# Altium schematic sheet main.SchDoc(main)
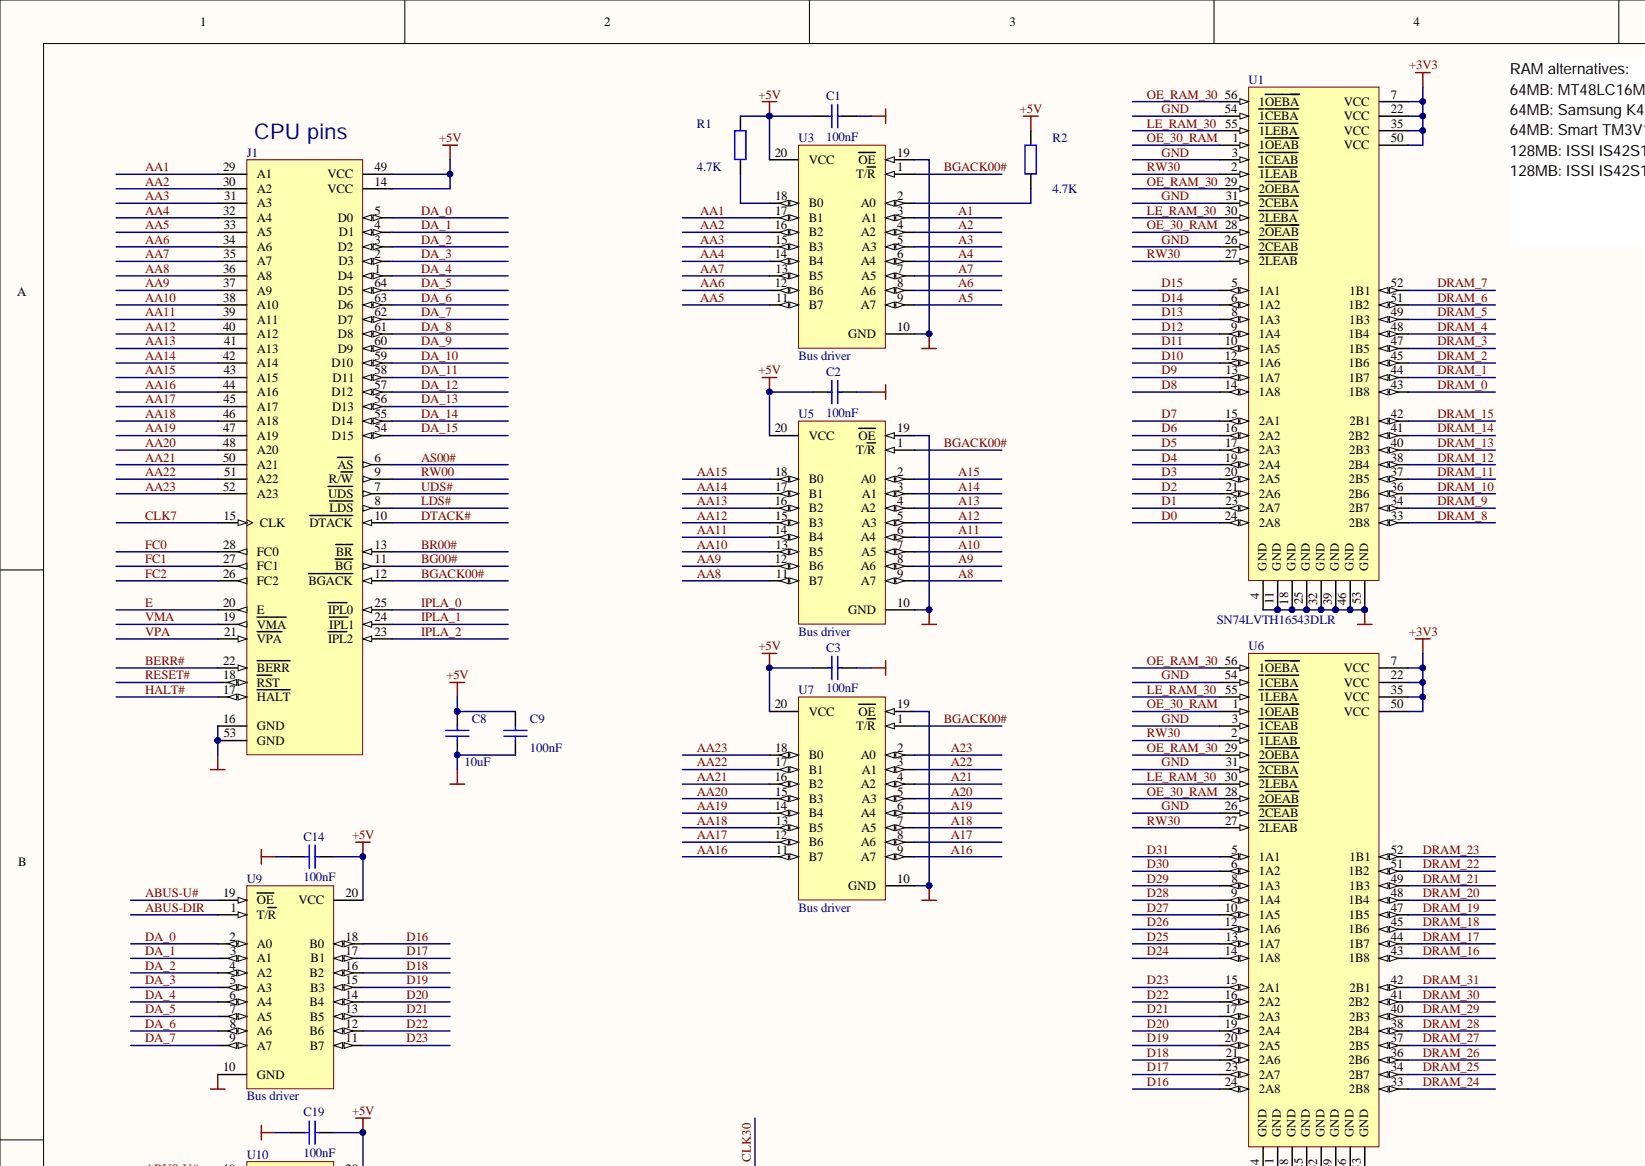
[diagram p0: main.SchDoc, top left]
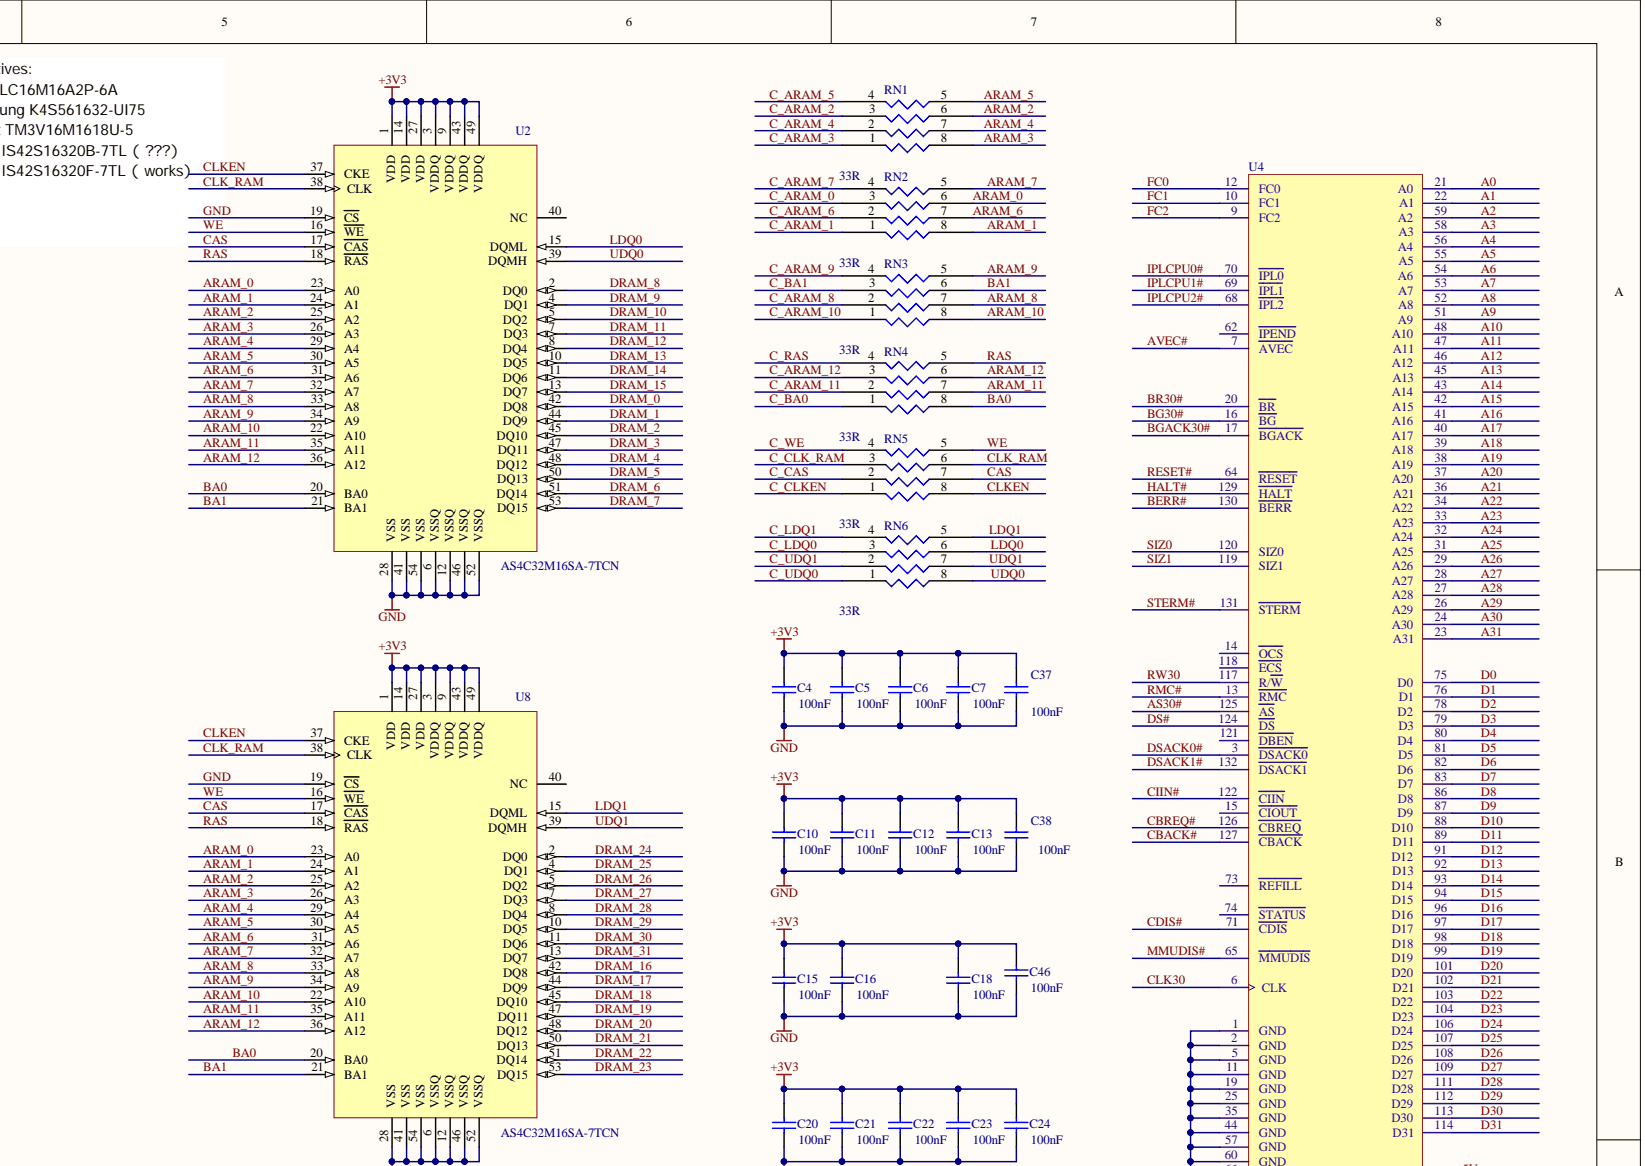
[diagram p1: main.SchDoc, top right]
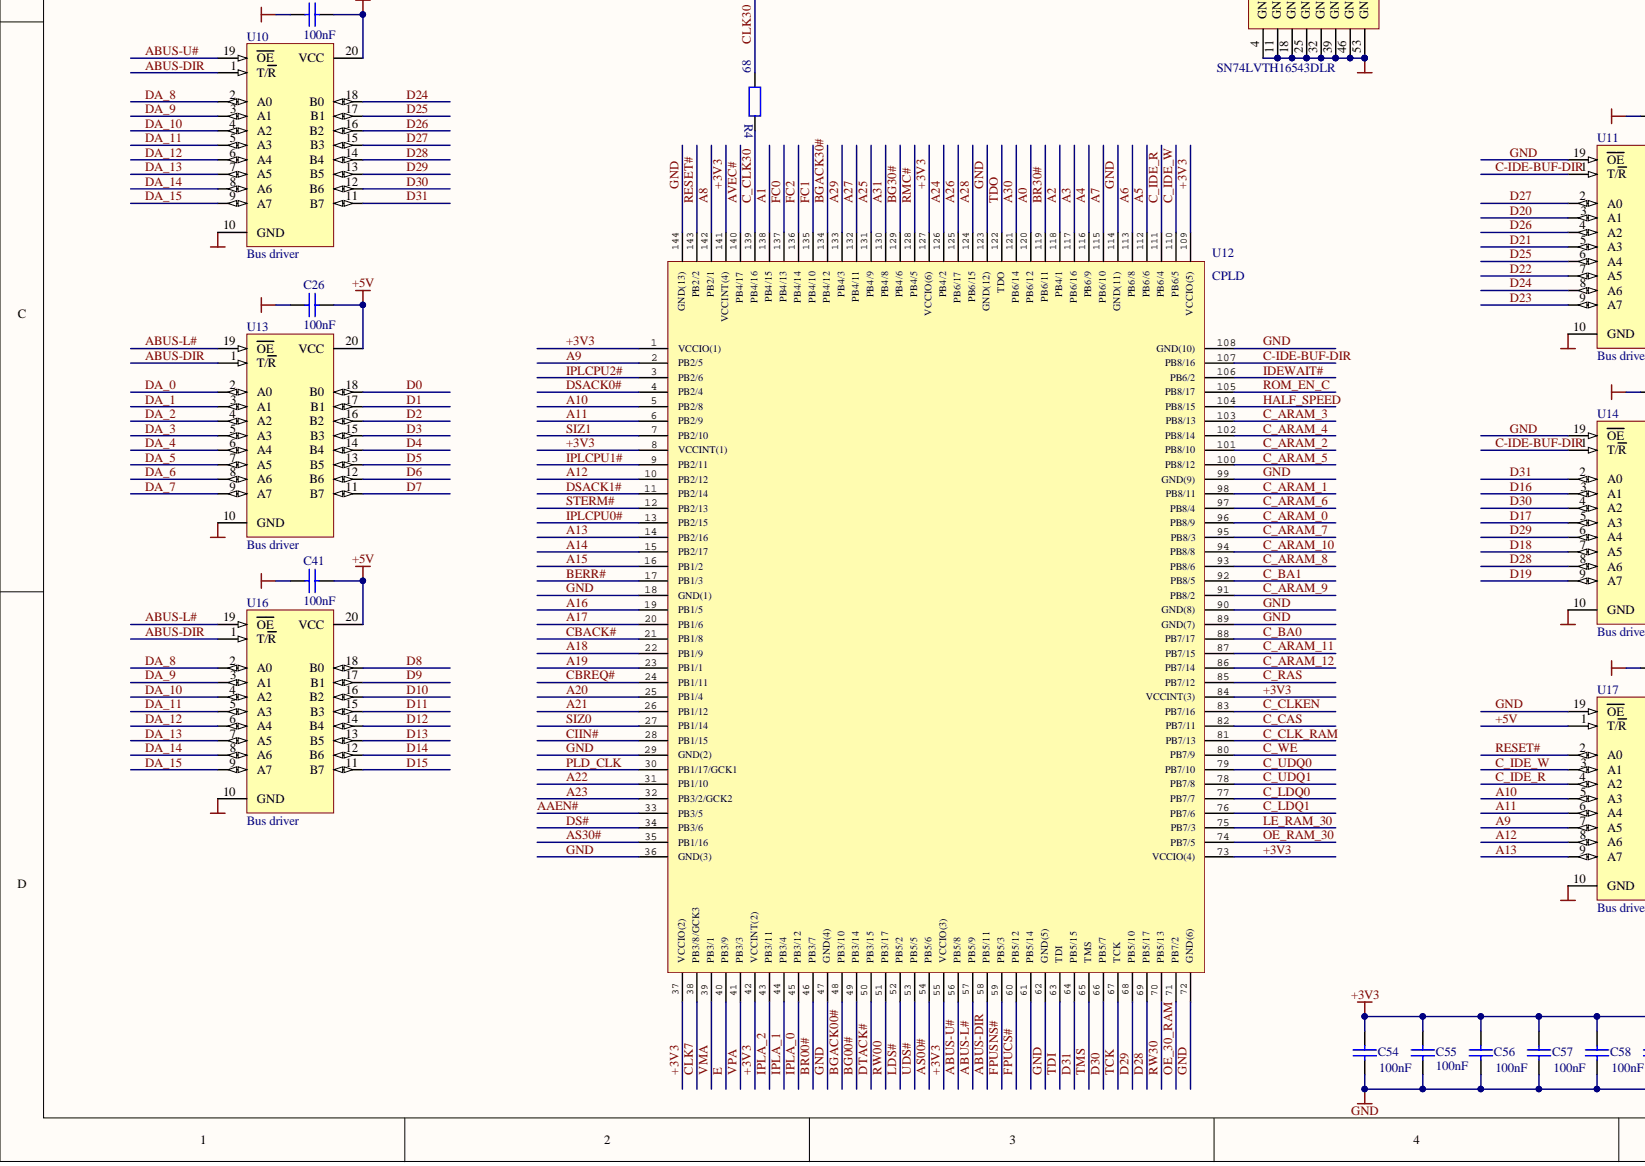
[diagram p2: main.SchDoc, bottom left]
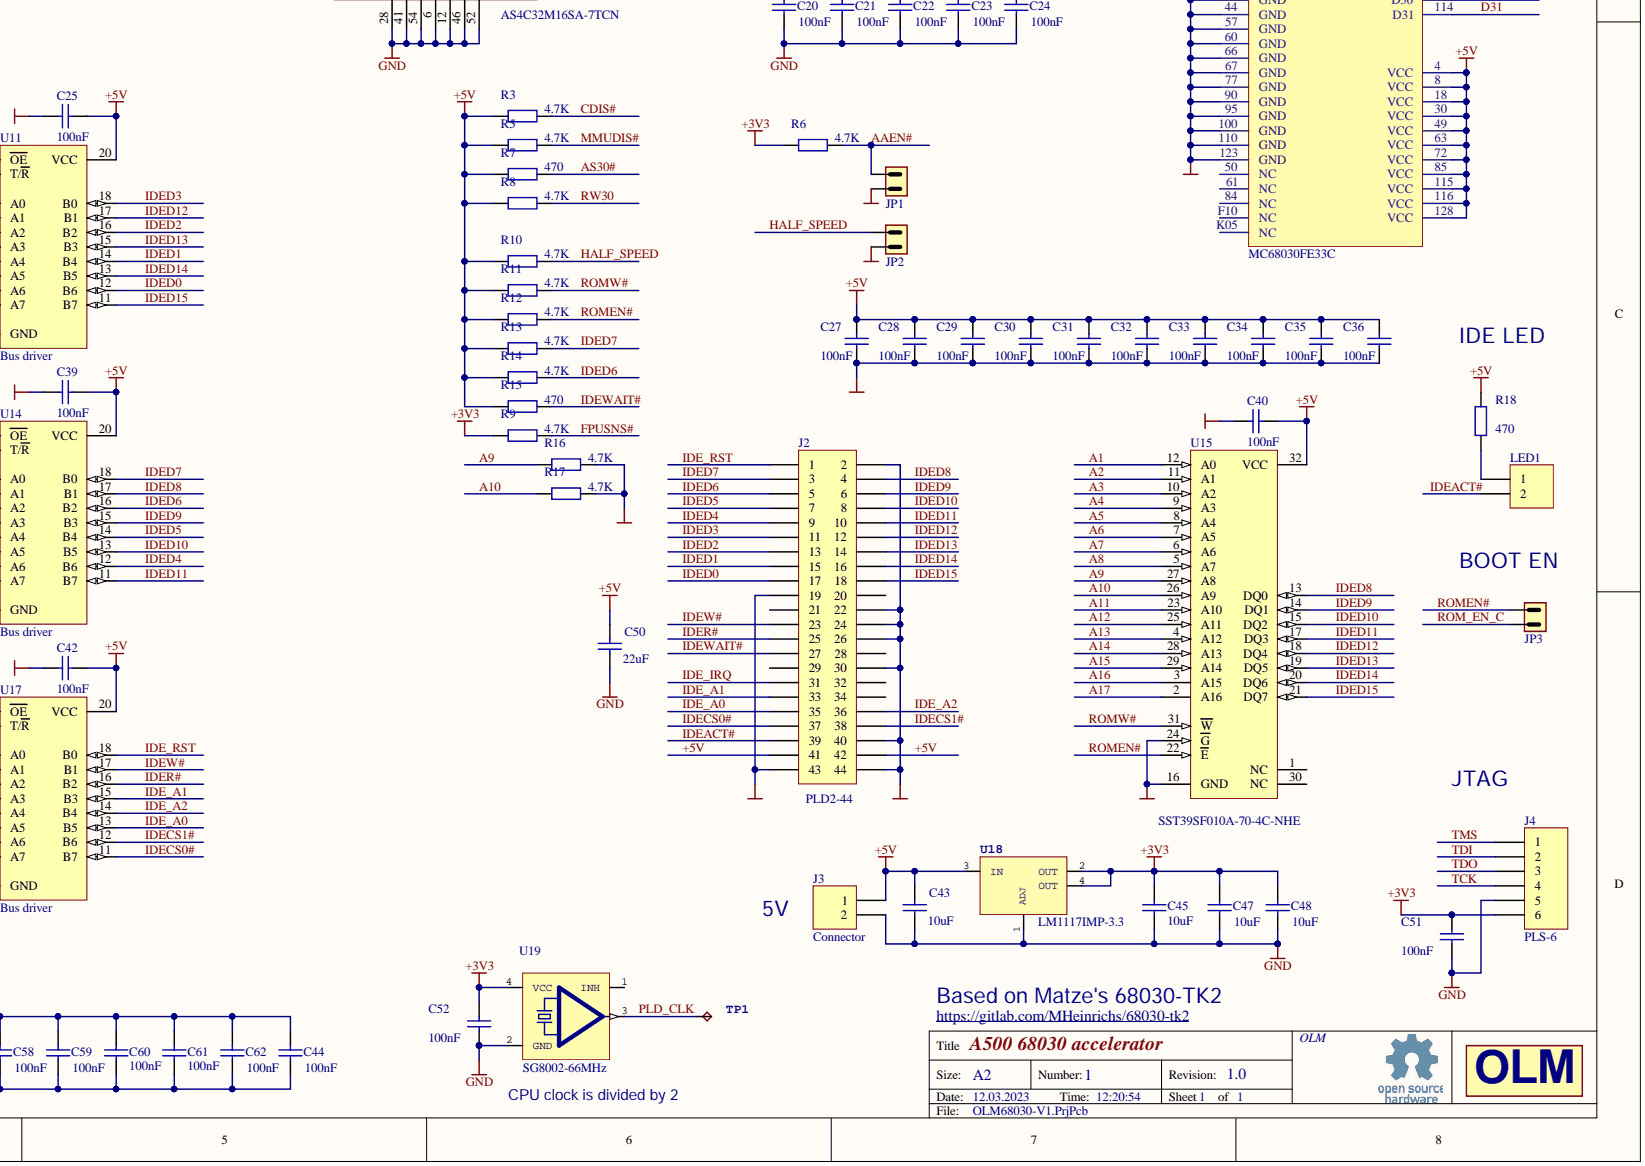
[diagram p3: main.SchDoc, bottom right]

## Parts
A1  OSHW  [OSHW-LOGO-10MM]  (value not drawn)  (no BOM)
C1  value 100nF  [SM/C_0603]  (hidden comment: Capacitor)
C2  value 100nF  [SM/C_0603]  (hidden comment: Capacitor)
C3  value 100nF  [SM/C_0603]  (hidden comment: Capacitor)
C4  value 100nF  [SM/C_0603]  (hidden comment: Capacitor)
C5  value 100nF  [SM/C_0603]  (hidden comment: Capacitor)
C6  value 100nF  [SM/C_0603]  (hidden comment: Capacitor)
C7  value 100nF  [SM/C_0603]  (hidden comment: Capacitor)
C8  value 10uF  [SM/C_1206]  (hidden comment: Capacitor)
C9  value 100nF  [SM/C_0603]  (hidden comment: Capacitor)
C10  value 100nF  [SM/C_0603]  (hidden comment: Capacitor)
C11  value 100nF  [SM/C_0603]  (hidden comment: Capacitor)
C12  value 100nF  [SM/C_0603]  (hidden comment: Capacitor)
C13  value 100nF  [SM/C_0603]  (hidden comment: Capacitor)
C14  value 100nF  [SM/C_0603]  (hidden comment: Capacitor)
C15  value 100nF  [SM/C_0603]  (hidden comment: Capacitor)
C16  value 100nF  [SM/C_0603]  (hidden comment: Capacitor)
C18  value 100nF  [SM/C_0603]  (hidden comment: Capacitor)
C19  value 100nF  [SM/C_0603]  (hidden comment: Capacitor)
C20  value 100nF  [SM/C_0603]  (hidden comment: Capacitor)
C21  value 100nF  [SM/C_0603]  (hidden comment: Capacitor)
C22  value 100nF  [SM/C_0603]  (hidden comment: Capacitor)
C23  value 100nF  [SM/C_0603]  (hidden comment: Capacitor)
C24  value 100nF  [SM/C_0603]  (hidden comment: Capacitor)
C25  value 100nF  [SM/C_0603]  (hidden comment: Capacitor)
C26  value 100nF  [SM/C_0603]  (hidden comment: Capacitor)
C27  value 100nF  [SM/C_0603]  (hidden comment: Capacitor)
C28  value 100nF  [SM/C_0603]  (hidden comment: Capacitor)
C29  value 100nF  [SM/C_0603]  (hidden comment: Capacitor)
C30  value 100nF  [SM/C_0603]  (hidden comment: Capacitor)
C31  value 100nF  [SM/C_0603]  (hidden comment: Capacitor)
C32  value 100nF  [SM/C_0603]  (hidden comment: Capacitor)
C33  value 100nF  [SM/C_0603]  (hidden comment: Capacitor)
C34  value 100nF  [SM/C_0603]  (hidden comment: Capacitor)
C35  value 100nF  [SM/C_0603]  (hidden comment: Capacitor)
C36  value 100nF  [SM/C_0603]  (hidden comment: Capacitor)
C37  value 100nF  [SM/C_0603]  (hidden comment: Capacitor)
C38  value 100nF  [SM/C_0603]  (hidden comment: Capacitor)
C39  value 100nF  [SM/C_0603]  (hidden comment: Capacitor)
C40  value 100nF  [SM/C_0603]  (hidden comment: Capacitor)
C41  value 100nF  [SM/C_0603]  (hidden comment: Capacitor)
C42  value 100nF  [SM/C_0603]  (hidden comment: Capacitor)
C43  value 10uF  [SM/C_1206]  (hidden comment: Capacitor)
C44  value 100nF  [SM/C_0603]  (hidden comment: Capacitor)
C45  value 10uF  [SM/C_1206]  (hidden comment: Capacitor)
C46  value 100nF  [SM/C_0603]  (hidden comment: Capacitor)
C47  value 10uF  [SM/C_1206]  (hidden comment: Capacitor)
C48  value 10uF  [SM/C_1206]  (hidden comment: Capacitor)
C50  value 22uF  [SM/C_1206]  (hidden comment: Capacitor)
C51  value 100nF  [SM/C_0603]  (hidden comment: Capacitor)
C52  value 100nF  [SM/C_0603]  (hidden comment: Capacitor)
C54  value 100nF  [SM/C_0603]  (hidden comment: Capacitor)
C55  value 100nF  [SM/C_0603]  (hidden comment: Capacitor)
C56  value 100nF  [SM/C_0603]  (hidden comment: Capacitor)
C57  value 100nF  [SM/C_0603]  (hidden comment: Capacitor)
C58  value 100nF  [SM/C_0603]  (hidden comment: Capacitor)
C59  value 100nF  [SM/C_0603]  (hidden comment: Capacitor)
C60  value 100nF  [SM/C_0603]  (hidden comment: Capacitor)
C61  value 100nF  [SM/C_0603]  (hidden comment: Capacitor)
C62  value 100nF  [SM/C_0603]  (hidden comment: Capacitor)
J1  Header pins  [DIP.100/64/W.900/L3.225-HEADER]  (value not drawn)
J2  Connector  value PLD2-44  [PLD2-44]
J3  Connector  [pls-2]
J4  Connector  value PLS-6  [PLS-6]  (no BOM)
JP1  Connector  [pls-2]  (no BOM)
JP2  Connector  [pls-2]  (no BOM)
JP3  Connector  [pls-2]
LED1  Connector  [pls-2]
R1  value 4.7K  [SM/R_0603]  (hidden comment: Resistor)
R2  value 4.7K  [SM/R_0603]  (hidden comment: Resistor)
R3  value 4.7K  [SM/R_0603]  (hidden comment: Resistor)
R4  value 68  [SM/R_0603]  (hidden comment: Resistor)
R5  value 4.7K  [SM/R_0603]  (hidden comment: Resistor)
R6  value 4.7K  [SM/R_0603]  (hidden comment: Resistor)
R7  value 470  [SM/R_0603]  (hidden comment: Resistor)
R8  value 4.7K  [SM/R_0603]  (hidden comment: Resistor)
R9  value 4.7K  [SM/R_0603]  (hidden comment: Resistor)
R10  value 4.7K  [SM/R_0603]  (hidden comment: Resistor)
R11  value 4.7K  [SM/R_0603]  (hidden comment: Resistor)
R12  value 4.7K  [SM/R_0603]  (hidden comment: Resistor)
R13  value 4.7K  [SM/R_0603]  (hidden comment: Resistor)
R14  value 4.7K  [SM/R_0603]  (hidden comment: Resistor)
R15  value 470  [SM/R_0603]  (hidden comment: Resistor)
R16  value 4.7K  [SM/R_0603]  (hidden comment: Resistor)
R17  value 4.7K  [SM/R_0603]  (hidden comment: Resistor)
R18  value 470  [SM/R_0603]  (hidden comment: Resistor)
RN1  Resistor network  [SM/RP_1206]  (value not drawn)
RN2  value 33R  [SM/RP_1206]  (hidden comment: Resistor network)
RN3  value 33R  [SM/RP_1206]  (hidden comment: Resistor network)
RN4  value 33R  [SM/RP_1206]  (hidden comment: Resistor network)
RN5  value 33R  [SM/RP_1206]  (hidden comment: Resistor network)
RN6  value 33R  [SM/RP_1206]  (hidden comment: Resistor network)
TP1  100MHz  [TP_d1.27]  (value not drawn)  (no BOM)
U1  Bus driver  value SN74LVTH16543DLR  [SSOP56]
U2  value AS4C32M16SA-7TCN  [TSOP2-54]  (hidden comment: SDRAM)
U3  Bus driver  [TSSOP20_6.5x4.4_0.65]
U4  value MC68030FE33C  [QUAD.025/132/WG1.085/CTX]  (hidden comment: CPU)
U5  Bus driver  [TSSOP20_6.5x4.4_0.65]
U6  Bus driver  value SN74LVTH16543DLR  [SSOP56]
U7  Bus driver  [TSSOP20_6.5x4.4_0.65]
U8  value AS4C32M16SA-7TCN  [TSOP2-54]  (hidden comment: SDRAM)
U9  Bus driver  [TSSOP20_6.5x4.4_0.65]
U10  Bus driver  [TSSOP20_6.5x4.4_0.65]
U11  Bus driver  [TSSOP20_6.5x4.4_0.65]
U12  CPLD  [QUAD.50M/144/WG22.00]
U13  Bus driver  [TSSOP20_6.5x4.4_0.65]
U14  Bus driver  [TSSOP20_6.5x4.4_0.65]
U15  value SST39SF010A-70-4C-NHE  [PLCC32-SOCKET]  (hidden comment: FLASH ROM)
U16  Bus driver  [TSSOP20_6.5x4.4_0.65]
U17  Bus driver  [TSSOP20_6.5x4.4_0.65]
U18  value LM1117IMP-3.3  [SM/SOT223_1234]  (hidden comment: LDO)
U19  value SG8002-66MHz  [SM/CRYSTAL_OSCILLATOR]  (hidden comment: OSCILLATOR)

## Nets
+3V3: C4.2 C5.2 C6.2 C7.2 C10.2 C11.2 C12.2 C13.2 C15.2 C16.2 C18.2 C20.2 C21.2 C22.2 C23.2 C24.2 C37.2 C38.2 C44.2 C45.1 C46.2 C47.2 C48.2 C51.2 C52.2 C54.2 C55.1 C56.2 C57.2 C58.2 C59.2 C60.1 C61.1 C62.2 J4.6 R6.1 R9.1 U1.7(VCC) U1.22(VCC) U1.35(VCC) U1.50(VCC) U2.1(VDD) U2.3(VDDQ) U2.9(VDDQ) U2.14(VDD) U2.27(VDD) U2.43(VDDQ) U2.49(VDDQ) U6.7(VCC) U6.22(VCC) U6.35(VCC) U6.50(VCC) U8.1(VDD) U8.3(VDDQ) U8.9(VDDQ) U8.14(VDD) U8.27(VDD) U8.43(VDDQ) U8.49(VDDQ) U12.1(VCCIO(1)) U12.8(VCCINT(1)) U12.37(VCCIO(2)) U12.42(VCCINT(2)) U12.55(VCCIO(3)) U12.73(VCCIO(4)) U12.84(VCCINT(3)) U12.109(VCCIO(5)) U12.127(VCCIO(6)) U12.141(VCCINT(4)) U18.2(OUT) U18.4(OUT) U19.4(VCC)
+5V: C1.2 C2.2 C3.2 C8.2 C9.2 C14.1 C19.1 C25.1 C26.1 C27.2 C28.2 C29.2 C30.2 C31.2 C32.2 C33.2 C34.2 C35.2 C36.2 C39.1 C40.1 C41.1 C42.1 C43.1 C50.1 J1.14(VCC) J1.49(VCC) J2.41 J2.42 J3.1 R1.2 R2.2 R3.1 R5.1 R7.1 R8.1 R10.1 R11.1 R12.1 R13.1 R14.1 R15.1 R18.2 U3.20(VCC) U4.4(VCC) U4.8(VCC) U4.18(VCC) U4.30(VCC) U4.49(VCC) U4.63(VCC) U4.72(VCC) U4.85(VCC) U4.115(VCC) U4.116(VCC) U4.128(VCC) U5.20(VCC) U7.20(VCC) U9.20(VCC) U10.20(VCC) U11.20(VCC) U13.20(VCC) U14.20(VCC) U15.32(VCC) U16.20(VCC) U17.1(T/R) U17.20(VCC) U18.3(IN)
A0: U4.21(A0) U12.120(PB6/12)
A1: U3.3(A1) U4.22(A1) U12.138(PB4/15) U15.12(A0)
A2: U3.4(A2) U4.59(A2) U12.118(PB4/1) U15.11(A1)
A3: U3.5(A3) U4.58(A3) U12.117(PB6/16) U15.10(A2)
A4: U3.6(A4) U4.56(A4) U12.116(PB6/9) U15.9(A3)
A5: U3.9(A7) U4.55(A5) U12.112(PB6/6) U15.8(A4)
A6: U3.8(A6) U4.54(A6) U12.113(PB6/8) U15.7(A5)
A7: U3.7(A5) U4.53(A7) U12.115(PB6/10) U15.6(A6)
A8: U4.52(A8) U5.9(A7) U12.142(PB2/1) U15.5(A7)
A9: R16.1 U4.51(A9) U5.8(A6) U12.2(PB2/5) U15.27(A8) U17.7(A5)
A10: R17.1 U4.48(A10) U5.7(A5) U12.5(PB2/8) U15.26(A9) U17.5(A3)
A11: U4.47(A11) U5.6(A4) U12.6(PB2/9) U15.23(A10) U17.6(A4)
A12: U4.46(A12) U5.5(A3) U12.10(PB2/12) U15.25(A11) U17.8(A6)
A13: U4.45(A13) U5.4(A2) U12.14(PB2/16) U15.4(A12) U17.9(A7)
A14: U4.43(A14) U5.3(A1) U12.15(PB2/17) U15.28(A13)
A15: U4.42(A15) U5.2(A0) U12.16(PB1/2) U15.29(A14)
A16: U4.41(A16) U7.9(A7) U12.19(PB1/5) U15.3(A15)
A17: U4.40(A17) U7.8(A6) U12.20(PB1/6) U15.2(A16)
A18: U4.39(A18) U7.7(A5) U12.22(PB1/9)
A19: U4.38(A19) U7.6(A4) U12.23(PB1/1)
A20: U4.37(A20) U7.5(A3) U12.25(PB1/4)
A21: U4.36(A21) U7.4(A2) U12.26(PB1/12)
A22: U4.34(A22) U7.3(A1) U12.31(PB1/10)
A23: U4.33(A23) U7.2(A0) U12.32(PB3/2/GCK2)
A24: U4.32(A24) U12.126(PB4/2)
A25: U4.31(A25) U12.131(PB4/9)
A26: U4.29(A26) U12.125(PB6/17)
A27: U4.28(A27) U12.132(PB4/11)
A28: U4.27(A28) U12.124(PB6/15)
A29: U4.26(A29) U12.133(PB4/3)
A30: U4.24(A30) U12.121(PB6/14)
A31: U4.23(A31) U12.130(PB4/8)
AA1: J1.29(A1) U3.17(B1)
AA2: J1.30(A2) U3.16(B2)
AA3: J1.31(A3) U3.15(B3)
AA4: J1.32(A4) U3.14(B4)
AA5: J1.33(A5) U3.11(B7)
AA6: J1.34(A6) U3.12(B6)
AA7: J1.35(A7) U3.13(B5)
AA8: J1.36(A8) U5.11(B7)
AA9: J1.37(A9) U5.12(B6)
AA10: J1.38(A10) U5.13(B5)
AA11: J1.39(A11) U5.14(B4)
AA12: J1.40(A12) U5.15(B3)
AA13: J1.41(A13) U5.16(B2)
AA14: J1.42(A14) U5.17(B1)
AA15: J1.43(A15) U5.18(B0)
AA16: J1.44(A16) U7.11(B7)
AA17: J1.45(A17) U7.12(B6)
AA18: J1.46(A18) U7.13(B5)
AA19: J1.47(A19) U7.14(B4)
AA20: J1.48(A20) U7.15(B3)
AA21: J1.50(A21) U7.16(B2)
AA22: J1.51(A22) U7.17(B1)
AA23: J1.52(A23) U7.18(B0)
AAEN#: JP1.2 R6.2 U12.33(PB3/5)
ABUS-DIR: U9.1(T/R) U10.1(T/R) U12.58(PB5/11) U13.1(T/R) U16.1(T/R)
ABUS-L#: U12.57(PB5/9) U13.19(OE) U16.19(OE)
ABUS-U#: U9.19(OE) U10.19(OE) U12.56(PB5/8)
ARAM_0: RN2.6 U2.23(A0) U8.23(A0)
ARAM_1: RN2.8 U2.24(A1) U8.24(A1)
ARAM_2: RN1.6 U2.25(A2) U8.25(A2)
ARAM_3: RN1.8 U2.26(A3) U8.26(A3)
ARAM_4: RN1.7 U2.29(A4) U8.29(A4)
ARAM_5: RN1.5 U2.30(A5) U8.30(A5)
ARAM_6: RN2.7 U2.31(A6) U8.31(A6)
ARAM_7: RN2.5 U2.32(A7) U8.32(A7)
ARAM_8: RN3.7 U2.33(A8) U8.33(A8)
ARAM_9: RN3.5 U2.34(A9) U8.34(A9)
ARAM_10: RN3.8 U2.22(A10) U8.22(A10)
ARAM_11: RN4.7 U2.35(A11) U8.35(A11)
ARAM_12: RN4.6 U2.36(A12) U8.36(A12)
AS00#: J1.6(AS) U12.54(PB5/6)
AS30#: R7.2 U4.125(AS) U12.35(PB1/16)
AVEC#: U4.7(AVEC) U12.140(PB4/17)
BA0: RN4.8 U2.20(BA0) U8.20(BA0)
BA1: RN3.6 U2.21(BA1) U8.21(BA1)
BERR#: J1.22(BERR) U4.130(BERR) U12.17(PB1/3)
BG00#: J1.11(BG) U12.49(PB3/14)
BG30#: U4.16(BG) U12.129(PB4/6)
BGACK00#: J1.12(BGACK) U3.1(T/R) U5.1(T/R) U7.1(T/R) U12.48(PB3/10)
BGACK30#: U4.17(BGACK) U12.134(PB4/12)
BR00#: J1.13(BR) U12.46(PB3/7)
BR30#: U4.20(BR) U12.119(PB6/11)
C-IDE-BUF-DIR: U11.1(T/R) U12.107(PB8/16) U14.1(T/R)
C_ARAM_0: RN2.3 U12.96(PB8/9)
C_ARAM_1: RN2.1 U12.98(PB8/11)
C_ARAM_2: RN1.3 U12.101(PB8/10)
C_ARAM_3: RN1.1 U12.103(PB8/13)
C_ARAM_4: RN1.2 U12.102(PB8/14)
C_ARAM_5: RN1.4 U12.100(PB8/12)
C_ARAM_6: RN2.2 U12.97(PB8/4)
C_ARAM_7: RN2.4 U12.95(PB8/3)
C_ARAM_8: RN3.2 U12.93(PB8/6)
C_ARAM_9: RN3.4 U12.91(PB8/2)
C_ARAM_10: RN3.1 U12.94(PB8/8)
C_ARAM_11: RN4.2 U12.87(PB7/15)
C_ARAM_12: RN4.3 U12.86(PB7/14)
C_BA0: RN4.1 U12.88(PB7/17)
C_BA1: RN3.3 U12.92(PB8/5)
C_CAS: RN5.2 U12.82(PB7/11)
C_CLK30: R4.1 U12.139(PB4/16)
C_CLK_RAM: RN5.3 U12.81(PB7/13)
C_CLKEN: RN5.1 U12.83(PB7/16)
C_IDE_R: U12.111(PB6/4) U17.4(A2)
C_IDE_W: U12.110(PB6/5) U17.3(A1)
C_LDQ0: RN6.3 U12.77(PB7/7)
C_LDQ1: RN6.4 U12.76(PB7/6)
C_RAS: RN4.4 U12.85(PB7/12)
C_UDQ0: RN6.1 U12.79(PB7/10)
C_UDQ1: RN6.2 U12.78(PB7/8)
C_WE: RN5.4 U12.80(PB7/9)
CAS: RN5.7 U2.17(CAS) U8.17(CAS)
CBACK#: U4.127(CBACK) U12.21(PB1/8)
CBREQ#: U4.126(CBREQ) U12.24(PB1/11)
CDIS#: R3.2 U4.71(CDIS)
CIIN#: U4.122(CIIN) U12.28(PB1/15)
CLK7: J1.15(CLK) U12.38(PB3/8/GCK3)
CLK30: R4.2 U4.6(CLK)
CLK_RAM: RN5.6 U2.38(CLK) U8.38(CLK)
CLKEN: RN5.8 U2.37(CKE) U8.37(CKE)
D0: U1.24(2A8) U4.75(D0) U13.18(B0)
D1: U1.23(2A7) U4.76(D1) U13.17(B1)
D2: U1.21(2A6) U4.78(D2) U13.16(B2)
D3: U1.20(2A5) U4.79(D3) U13.15(B3)
D4: U1.19(2A4) U4.80(D4) U13.14(B4)
D5: U1.17(2A3) U4.81(D5) U13.13(B5)
D6: U1.16(2A2) U4.82(D6) U13.12(B6)
D7: U1.15(2A1) U4.83(D7) U13.11(B7)
D8: U1.14(1A8) U4.86(D8) U16.18(B0)
D9: U1.13(1A7) U4.87(D9) U16.17(B1)
D10: U1.12(1A6) U4.88(D10) U16.16(B2)
D11: U1.10(1A5) U4.89(D11) U16.15(B3)
D12: U1.9(1A4) U4.91(D12) U16.14(B4)
D13: U1.8(1A3) U4.92(D13) U16.13(B5)
D14: U1.6(1A2) U4.93(D14) U16.12(B6)
D15: U1.5(1A1) U4.94(D15) U16.11(B7)
D16: U4.96(D16) U6.24(2A8) U9.18(B0) U14.3(A1)
D17: U4.97(D17) U6.23(2A7) U9.17(B1) U14.5(A3)
D18: U4.98(D18) U6.21(2A6) U9.16(B2) U14.7(A5)
D19: U4.99(D19) U6.20(2A5) U9.15(B3) U14.9(A7)
D20: U4.101(D20) U6.19(2A4) U9.14(B4) U11.3(A1)
D21: U4.102(D21) U6.17(2A3) U9.13(B5) U11.5(A3)
D22: U4.103(D22) U6.16(2A2) U9.12(B6) U11.7(A5)
D23: U4.104(D23) U6.15(2A1) U9.11(B7) U11.9(A7)
D24: U4.106(D24) U6.14(1A8) U10.18(B0) U11.8(A6)
D25: U4.107(D25) U6.13(1A7) U10.17(B1) U11.6(A4)
D26: U4.108(D26) U6.12(1A6) U10.16(B2) U11.4(A2)
D27: U4.109(D27) U6.10(1A5) U10.15(B3) U11.2(A0)
D28: U4.111(D28) U6.9(1A4) U10.14(B4) U12.69(PB5/17) U14.8(A6)
D29: U4.112(D29) U6.8(1A3) U10.13(B5) U12.68(PB5/10) U14.6(A4)
D30: U4.113(D30) U6.6(1A2) U10.12(B6) U12.66(PB5/7) U14.4(A2)
D31: U4.114(D31) U6.5(1A1) U10.11(B7) U12.64(PB5/15) U14.2(A0)
DA_0: J1.5(D0) U9.2(A0) U13.2(A0)
DA_1: J1.4(D1) U9.3(A1) U13.3(A1)
DA_2: J1.3(D2) U9.4(A2) U13.4(A2)
DA_3: J1.2(D3) U9.5(A3) U13.5(A3)
DA_4: J1.1(D4) U9.6(A4) U13.6(A4)
DA_5: J1.64(D5) U9.7(A5) U13.7(A5)
DA_6: J1.63(D6) U9.8(A6) U13.8(A6)
DA_7: J1.62(D7) U9.9(A7) U13.9(A7)
DA_8: J1.61(D8) U10.2(A0) U16.2(A0)
DA_9: J1.60(D9) U10.3(A1) U16.3(A1)
DA_10: J1.59(D10) U10.4(A2) U16.4(A2)
DA_11: J1.58(D11) U10.5(A3) U16.5(A3)
DA_12: J1.57(D12) U10.6(A4) U16.6(A4)
DA_13: J1.56(D13) U10.7(A5) U16.7(A5)
DA_14: J1.55(D14) U10.8(A6) U16.8(A6)
DA_15: J1.54(D15) U10.9(A7) U16.9(A7)
DRAM_0: U1.43(1B8) U2.42(DQ8)
DRAM_1: U1.44(1B7) U2.44(DQ9)
DRAM_2: U1.45(1B6) U2.45(DQ10)
DRAM_3: U1.47(1B5) U2.47(DQ11)
DRAM_4: U1.48(1B4) U2.48(DQ12)
DRAM_5: U1.49(1B3) U2.50(DQ13)
DRAM_6: U1.51(1B2) U2.51(DQ14)
DRAM_7: U1.52(1B1) U2.53(DQ15)
DRAM_8: U1.33(2B8) U2.2(DQ0)
DRAM_9: U1.34(2B7) U2.4(DQ1)
DRAM_10: U1.36(2B6) U2.5(DQ2)
DRAM_11: U1.37(2B5) U2.7(DQ3)
DRAM_12: U1.38(2B4) U2.8(DQ4)
DRAM_13: U1.40(2B3) U2.10(DQ5)
DRAM_14: U1.41(2B2) U2.11(DQ6)
DRAM_15: U1.42(2B1) U2.13(DQ7)
DRAM_16: U6.43(1B8) U8.42(DQ8)
DRAM_17: U6.44(1B7) U8.44(DQ9)
DRAM_18: U6.45(1B6) U8.45(DQ10)
DRAM_19: U6.47(1B5) U8.47(DQ11)
DRAM_20: U6.48(1B4) U8.48(DQ12)
DRAM_21: U6.49(1B3) U8.50(DQ13)
DRAM_22: U6.51(1B2) U8.51(DQ14)
DRAM_23: U6.52(1B1) U8.53(DQ15)
DRAM_24: U6.33(2B8) U8.2(DQ0)
DRAM_25: U6.34(2B7) U8.4(DQ1)
DRAM_26: U6.36(2B6) U8.5(DQ2)
DRAM_27: U6.37(2B5) U8.7(DQ3)
DRAM_28: U6.38(2B4) U8.8(DQ4)
DRAM_29: U6.40(2B3) U8.10(DQ5)
DRAM_30: U6.41(2B2) U8.11(DQ6)
DRAM_31: U6.42(2B1) U8.13(DQ7)
DS#: U4.124(DS) U12.34(PB3/6)
DSACK0#: U4.3(DSACK0) U12.4(PB2/4)
DSACK1#: U4.132(DSACK1) U12.11(PB2/14)
DTACK#: J1.10(DTACK) U12.50(PB3/15)
E: J1.20(E) U12.40(PB3/9)
FC0: J1.28(FC0) U4.12(FC0) U12.137(PB4/13)
FC1: J1.27(FC1) U4.10(FC1) U12.135(PB4/10)
FC2: J1.26(FC2) U4.9(FC2) U12.136(PB4/14)
FPUCS#: U12.60(PB5/12)
FPUSNS#: R9.2 U12.59(PB5/3)
GND: C1.1 C2.1 C3.1 C4.1 C5.1 C6.1 C7.1 C8.1 C9.1 C10.1 C11.1 C12.1 C13.1 C14.2 C15.1 C16.1 C18.1 C19.2 C20.1 C21.1 C22.1 C23.1 C24.1 C25.2 C26.2 C27.1 C28.1 C29.1 C30.1 C31.1 C32.1 C33.1 C34.1 C35.1 C36.1 C37.1 C38.1 C39.2 C40.2 C41.2 C42.2 C43.2 C44.1 C45.2 C46.1 C47.1 C48.1 C50.2 C51.1 C52.1 C54.1 C55.2 C56.1 C57.1 C58.1 C59.1 C60.2 C61.2 C62.1 J1.16(GND) J1.53(GND) J2.2 J2.19 J2.22 J2.24 J2.26 J2.30 J2.40 J2.43 J2.44 J3.2 J4.5 JP1.1 JP2.1 R16.2 R17.2 U1.3(1CEAB) U1.4(GND) U1.11(GND) U1.18(GND) U1.25(GND) U1.26(2CEAB) U1.31(2CEBA) U1.32(GND) U1.39(GND) U1.46(GND) U1.53(GND) U1.54(1CEBA) U2.6(VSSQ) U2.12(VSSQ) U2.19(CS) U2.28(VSS) U2.41(VSS) U2.46(VSSQ) U2.52(VSSQ) U2.54(VSS) U3.10(GND) U3.19(OE) U4.1(GND) U4.2(GND) U4.5(GND) U4.11(GND) U4.19(GND) U4.25(GND) U4.35(GND) U4.44(GND) U4.57(GND) U4.60(GND) U4.66(GND) U4.67(GND) U4.77(GND) U4.90(GND) U4.95(GND) U4.100(GND) U4.110(GND) U4.123(GND) U5.10(GND) U5.19(OE) U6.3(1CEAB) U6.4(GND) U6.11(GND) U6.18(GND) U6.25(GND) U6.26(2CEAB) U6.31(2CEBA) U6.32(GND) U6.39(GND) U6.46(GND) U6.53(GND) U6.54(1CEBA) U7.10(GND) U7.19(OE) U8.6(VSSQ) U8.12(VSSQ) U8.19(CS) U8.28(VSS) U8.41(VSS) U8.46(VSSQ) U8.52(VSSQ) U8.54(VSS) U9.10(GND) U10.10(GND) U11.10(GND) U11.19(OE) U12.18(GND(1)) U12.29(GND(2)) U12.36(GND(3)) U12.47(GND(4)) U12.62(GND(5)) U12.72(GND(6)) U12.89(GND(7)) U12.90(GND(8)) U12.99(GND(9)) U12.108(GND(10)) U12.114(GND(11)) U12.123(GND(12)) U12.144(GND(13)) U13.10(GND) U14.10(GND) U14.19(OE) U15.16(GND) U15.24(G) U16.10(GND) U17.10(GND) U17.19(OE) U18.1(ADJ) U19.2(GND)
HALF_SPEED: JP2.2 R10.2 U12.104(PB8/15)
HALT#: J1.17(HALT) U4.129(HALT)
IDE_A0: J2.35 U17.13(B5)
IDE_A1: J2.33 U17.15(B3)
IDE_A2: J2.36 U17.14(B4)
IDE_IRQ: J2.31
IDE_RST: J2.1 U17.18(B0)
IDEACT#: J2.39 LED1.2
IDECS0#: J2.37 U17.11(B7)
IDECS1#: J2.38 U17.12(B6)
IDED0: J2.17 U11.12(B6)
IDED1: J2.15 U11.14(B4)
IDED2: J2.13 U11.16(B2)
IDED3: J2.11 U11.18(B0)
IDED4: J2.9 U14.12(B6)
IDED5: J2.7 U14.14(B4)
IDED6: J2.5 R14.2 U14.16(B2)
IDED7: J2.3 R13.2 U14.18(B0)
IDED8: J2.4 U14.17(B1) U15.13(DQ0)
IDED9: J2.6 U14.15(B3) U15.14(DQ1)
IDED10: J2.8 U14.13(B5) U15.15(DQ2)
IDED11: J2.10 U14.11(B7) U15.17(DQ3)
IDED12: J2.12 U11.17(B1) U15.18(DQ4)
IDED13: J2.14 U11.15(B3) U15.19(DQ5)
IDED14: J2.16 U11.13(B5) U15.20(DQ6)
IDED15: J2.18 U11.11(B7) U15.21(DQ7)
IDER#: J2.25 U17.16(B2)
IDEW#: J2.23 U17.17(B1)
IDEWAIT#: J2.27 R15.2 U12.106(PB6/2)
IPLA_0: J1.25(IPL0) U12.45(PB3/12)
IPLA_1: J1.24(IPL1) U12.44(PB3/4)
IPLA_2: J1.23(IPL2) U12.43(PB3/11)
IPLCPU0#: U4.70(IPL0) U12.13(PB2/15)
IPLCPU1#: U4.69(IPL1) U12.9(PB2/11)
IPLCPU2#: U4.68(IPL2) U12.3(PB2/6)
LDQ0: RN6.6 U2.15(DQML)
LDQ1: RN6.5 U8.15(DQML)
LDS#: J1.8(LDS) U12.52(PB5/2)
LE_RAM_30: U1.30(2LEBA) U1.55(1LEBA) U6.30(2LEBA) U6.55(1LEBA) U12.75(PB7/3)
MMUDIS#: R5.2 U4.65(MMUDIS)
OE_30_RAM: U1.1(1OEAB) U1.28(2OEAB) U6.1(1OEAB) U6.28(2OEAB) U12.71(PB7/2)
OE_RAM_30: U1.29(2OEBA) U1.56(1OEBA) U6.29(2OEBA) U6.56(1OEBA) U12.74(PB7/5)
PLD_CLK: TP1.TP U12.30(PB1/17/GCK1) U19.3
RAS: RN4.5 U2.18(RAS) U8.18(RAS)
RESET#: J1.18(RST) U4.64(RESET) U12.143(PB2/2) U17.2(A0)
RMC#: U4.13(RMC) U12.128(PB4/5)
ROM_EN_C: JP3.1 U12.105(PB8/17)
ROMEN#: JP3.2 R12.2 U15.22(E)
ROMW#: R11.2 U15.31(W)
RW00: J1.9(R/W) U12.51(PB3/17)
RW30: R8.2 U1.2(1LEAB) U1.27(2LEAB) U4.117(R/W) U6.2(1LEAB) U6.27(2LEAB) U12.70(PB5/13)
SIZ0: U4.120(SIZ0) U12.27(PB1/14)
SIZ1: U4.119(SIZ1) U12.7(PB2/10)
STERM#: U4.131(STERM) U12.12(PB2/13)
TCK: J4.4 U12.67(TCK)
TDI: J4.2 U12.63(TDI)
TDO: J4.3 U12.122(TDO)
TMS: J4.1 U12.65(TMS)
UDQ0: RN6.8 U2.39(DQMH)
UDQ1: RN6.7 U8.39(DQMH)
UDS#: J1.7(UDS) U12.53(PB5/5)
VMA: J1.19(VMA) U12.39(PB3/1)
VPA: J1.21(VPA) U12.41(PB3/3)
WE: RN5.5 U2.16(WE) U8.16(WE)
NetLED1_1: LED1.1 R18.1
NetR1_1: R1.1 U3.18(B0)
NetR2_1: R2.1 U3.2(A0)

Not connected: J2 20 21 28 29 32 34; U2 40(NC); U4 14(OCS) 15(CIOUT) 50(NC) 61(NC) 62(IPEND) 73(REFILL) 74(STATUS) 84(NC) 118(ECS) 121(DBEN) F10(NC) K05(NC); U8 40(NC); U12 61(PB5/14); U15 1(NC) 30(NC); U19 1(INH)

## Library data of the parts
C1-C3 C9 C14 C19 C25-C42 = Cap ceramic: Ceramic capacitor SMD; Manufacturer: Samsung; Part: CL10B104KB8NNNL; Component Kind: Standard; Library Name: New.SCHLIB
C4-C7 C10-C13 C15 C16 C18 C20-C24 C43-C48 C50-C52 C54-C62 = Cap: Component Kind: Standard; Description: Capacitor; LatestRevisionDate: 17-Jul-2002; LatestRevisionNote: Re-released for DXP Platform.; Library Name: Miscellaneous Devices.IntLib; PackageDescription: Radial Cap, Thru-Hole; 2 Leads; 0.3 in Pin Spacing; PackageReference: RAD-0.3; Signal Integrity: Cap; Simulation: CAP; Publisher: Altium Limited
C8 = Cap ceramic: Ceramic capacitor SMD; Manufacturer: Kemet; Part: C1206C106K4RACTU; Component Kind: Standard; Library Name: New.SCHLIB
J1 = MC68000P: 64 combined socket/pins; Value: Round pins 2x 32, 2.54mm pitch; Component Kind: Standard; DatasheetVersion: 1990; LatestRevisionDate: 06-Jun-2005; LatestRevisionNote: Stylized 3D Model Added.; PackageDescription: DIP; 64 Leads; Row Spacing 22.86 mm; Pitch 2.54 mm; PackageReference: 754-01; PCB3D: 746-01; Published: 17-Jul-2002; Library Name: Motorola Microprocessor 16-Bit.IntLib; Publisher: Altium Limited
J2 = Header 22X2: Header, 22-Pin, Dual row; Component Kind: Standard; LatestRevisionDate: 17-Jul-2002; LatestRevisionNote: Re-released for DXP Platform.; Library Name: Miscellaneous Connectors.IntLib; Signal Integrity: Connector; Publisher: Altium Limited
J3 LED1 = Header 2: Header, 2-Pin; Value: PLS-2; Component Kind: Standard; LatestRevisionDate: 17-Jul-2002; LatestRevisionNote: Re-released for DXP Platform.; Library Name: Miscellaneous Connectors.IntLib; Signal Integrity: Connector; Publisher: Altium Limited
J4 = Header 6: Header, 6-Pin; LatestRevisionDate: 17-Jul-2002; LatestRevisionNote: Re-released for DXP Platform.; Library Name: Miscellaneous Connectors.IntLib; Signal Integrity: Connector; Publisher: Altium Limited
JP1 JP2 = Jumper2: Jumper Wire; Manufacturer: Generic Components; Value: PLS-2; Library Name: New_home.SCHLIB; Published: 1999
JP3 = Jumper2: Jumper Wire; Manufacturer: Generic Components; Value: PLS-2; Value: PLS-2; Component Kind: Standard; Library Name: New_home.SCHLIB; Published: 1999
R1-R18 = Res2: Resistor SMD; Part: 4.7k 5% 0603; Component Kind: Standard; LatestRevisionDate: 17-Jul-2002; LatestRevisionNote: Re-released for DXP Platform.; Library Name: Miscellaneous Devices.IntLib; PackageReference: AXIAL-0.4; Published: 8-Jun-2000; Signal Integrity: Res; Simulation: RESISTOR; Publisher: Altium Limited
RN1 = RESAR_IS_4/SM: Value: 33R; Component Kind: Standard; Library Name: Discrete_Library.SchLib
RN2-RN6 = RESAR_IS_4/SM: Component Kind: Standard; Library Name: Discrete_Library.SchLib
TP1 = TPB1,27: Test pad; Library Name: testpad.IntLib
U1 U6 = 74ACT16543DL: 16-Bit Registered Transceiver with 3-State Outputs; Code_IPC: SSO56; Code_JEDEC: MO-118-AB; Component Kind: Standard; ComponentLink1Description: Manufacturer Link; ComponentLink1URL: http://www.ti.com/; ComponentLink2Description: Datasheet; ComponentLink2URL: http://www-s.ti.com/sc/ds/74act16543.pdf; DatasheetVersion: Apr-1996; LatestRevisionDate: 04-Mar-2005; LatestRevisionNote: Stylized 3D Model Added.; Library Name: TI Interface Line Transceiver.IntLib; PackageDescription: SSOP; 56 Leads; Body Width 7.5 mm; Pitch 0.635 mm; PackageReference: DL056; PackageVersion: Dec-2001; PCB3D: DL056; Published: 8-Jun-2000; Signal Integrity: 74ACT16543DL; Publisher: Altium Limited
U2 U8 = MT48LC16M16A2P-6A: 256Mb Synchronous High-Speed CMOS DRAM, 16Mb x 16Bit x 4 Banks, 54-Pin TSOP Pb-free, Commercial; ColumnAddress: 512 (A0-A8); CycleTime: 6.0ns @ CL = 3; RowAddress: 8K (A0-A12); Code_JEDEC: MS-024-FA; Component Kind: Standard; ComponentLink1Description: Manufacturer Link; ComponentLink1URL: http://www.micron.com; ComponentLink2Description: Datasheet; ComponentLink2URL: http://download.micron.com/pdf/datasheets/dram/sdram/256MSDRAM.pdf; DatasheetVersion: RevL, Oct-2007; LatestRevisionDate: 13-Apr-2006; LatestRevisionNote: IPC-7351 Footprint Added.; Library Name: Micron Memory Dynamic RAM.SchLib; PackageDescription: 54-Pin Plastic Small Outline Package 0.8 mm Pitch; PackageReference: TSOP2-54; PackageVersion: Sep-2003; Published: 11-Oct-2004; Publisher: Altium Limited
U3 U5 U7 U9-U11 U13 U14 U16 U17 = SN74ABT245DW: Octal Bus Transceiver with 3-State Outputs; ComponentAvailability: Obsolete; Value: 74ABT245PW,118; Code_IPC: SOIC127P1030-20; Code_JEDEC: MS-013; Component Kind: Standard; ComponentLink1Description: Manufacturer Link; ComponentLink1URL: http://www.ti.com/; ComponentLink2Description: URL Link1; ComponentLink2URL: http://focus.ti.com/docs/prod/folders/print/sn74abt245.html; DatasheetVersion: Jul-1994; LatestRevisionDate: 13-Apr-2006; LatestRevisionNote: IPC-7351 Footprint Added.; Library Name: TI Interface 8-Bit Line Transceiver.IntLib; PackageDescription: 20-Pin Plastic Small Outline Integrated Circuit 1.27 mm Pitch; PackageReference: DW020; PackageVersion: Mar-2000; PCB3D: DW020; Published: 8-Jun-2000; Signal Integrity: SN74ABT245DW; Publisher: Altium Limited
U4 = MC68030FE: Component Kind: Standard; Library Name: Motorola Microprocessor 32-Bit.SchLib
U12 = XC95144XL-10TQG144I: XC95144XL-10TQG144I XC95144XL-10TQG144I, CPLD XC9500XL Flash 144 Cells, 117 I/O, 8 Labs, ISP, 3  3.6 V 144-Pin TQFP       Source:  Datasheet; Value: XC95288XL-10TQG144I; Component Kind: Standard; Library Name: Xilinx_CPLD.SchLib
U15 = TMS29F010FM: 1 048 576-Bit Flash/Block EEPROM; Component Kind: Standard; ComponentLink1Description: Manufacturer Link; ComponentLink1URL: http://www.ti.com/; DatasheetVersion: Dec-1990; LatestRevisionDate: 08-Jul-2005; LatestRevisionNote: Stylized 3D Model Added.; Library Name: TI Memory EEPROM.IntLib; PackageDescription: Leaded Chip Carrier; 32 Leads; Body 11.4 x 14 mm; PackageReference: FM32; PackageVersion: 1991; PCB3D: TMS29F010-FM32; Published: 15-Apr-2002; Publisher: Altium Limited
U18 = LM1117-SOT223: Voltage Regulator LM1117Standard adjustable voltage regulator but in SMD form. Spark Fun Electronics SKU : COM-00595; Component Kind: Standard; Library Name: Power.SchLib
U19 = OSCILLATOR: Oscillators   3.2x2.5mm SMT 4.0MHz +/- 50ppm, 3.3V, 9mA, -40/+85°C - Digikey: 535-9752-1-ND  50MHz +/- 50ppm, 3.3V, 8mA, -10/+70°C - Digikey: 478-4792-1-ND       5x7mm SMT 4.0MHz +/-20ppm 3.3V, 20mA, -20/+70°C - Digikey: 535-9329-2-ND  50MHz +/- 25ppm 3.3V, 35mA, -40/+85°C; Component Kind: Standard; Library Name: New_home.SCHLIB
A1 = OSHW: Description: OSHW; Library Name: MECH_Library_home.SchLib
